# Altium schematic sheet Power.SchDoc
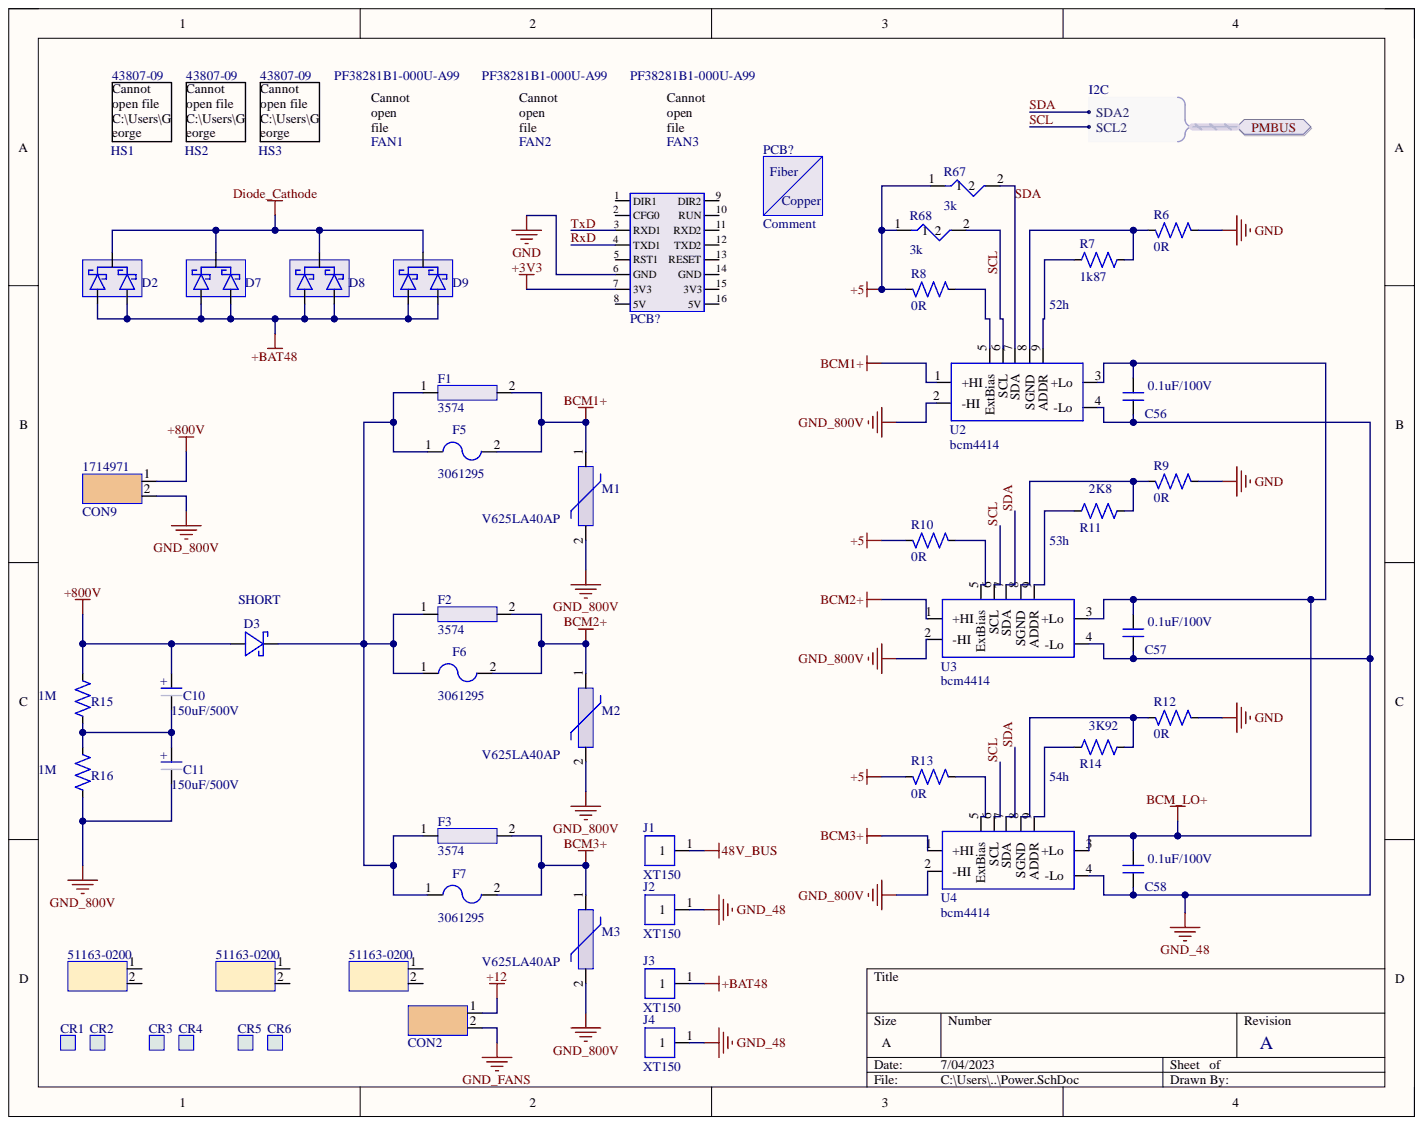
[diagram p0: Power.SchDoc]

## Parts
C10  150uF/500V  [Cap_THT_O22mm-Lead10mm]
C11  150uF/500V  [Cap_THT_O22mm-Lead10mm]
C56  0.1uF/100V  [C0805]
C57  0.1uF/100V  [C0805]
C58  0.1uF/100V  [C0805]
CON2  22-28-4024  [Con1x02_2.54]  (value not drawn)
CON9  1714971  [CON1X02_9.52mm]
CR1  (no value)
CR2  (no value)
CR3  (no value)
CR4  (no value)
CR5  (no value)
CR6  (no value)
D2  VS-80CPQ020-N3  [TO-247]  (value not drawn)
D3  (no value)  [TO-220]
D7  VS-80CPQ020-N3  [TO-247]  (value not drawn)
D8  VS-80CPQ020-N3  [TO-247]  (value not drawn)
D9  VS-80CPQ020-N3  [TO-247]  (value not drawn)
F1  3574  [5AG_Holder]
F2  3574  [5AG_Holder]
F3  3574  [5AG_Holder]
F5  3061295
F6  3061295
F7  3061295
FAN1  PF38281B1-000U-A99
FAN2  PF38281B1-000U-A99
FAN3  PF38281B1-000U-A99
HS1  43807-09
HS2  43807-09
HS3  43807-09
J1  XT150  [XT150]
J2  XT150  [XT150]
J3  XT150  [XT150]
J4  XT150  [XT150]
M1  V625LA40AP  [V625LA40AP]
M2  V625LA40AP  [V625LA40AP]
M3  V625LA40AP  [V625LA40AP]
PCB?  Comment  [TP-Link MC220L]
PCB?  Uart to Ethernet Converter  [uart2ethernet]  (value not drawn)
R6  0R  [R0402]
R7  1k87  [R0402]
R8  0R  [R0402]
R9  0R  [R0402]
R10  0R  [R0402]
R11  2K8  [R0402]
R12  0R  [R0402]
R13  0R  [R0402]
R14  3K92  [R0402]
R15  1M  [R2010]
R16  1M  [R2010]
R67  3k  [0805_3k]
R68  3k  [0805_3k]
U2  bcm4414  [BCM4414]
U3  bcm4414  [BCM4414]
U4  bcm4414  [BCM4414]

## Ports
PMBUS, PMBUS.SCL2, PMBUS.SDA2

## Nets
48V_BUS: J1.1
+3V3: PCB?.7(3V3)
+5: R8.2 R10.2 R13.2 R67.1 R68.1
+12: CON2.1
+800V: C10.1 CON9.1 D3.2 R15.2
+BAT48: D2.1 D2.3 D7.1 D7.3 D8.1 D8.3 D9.1 D9.3 J3.1
BCM1+: F1.2 F5.2 M1.1 U2.1(+HI)
BCM2+: F2.2 F6.2 M2.1 U3.1(+HI)
BCM3+: F3.2 F7.2 M3.1 U4.1(+HI)
BCM_LO+: C56.1 C57.1 C58.1 U2.3(+Lo) U3.3(+Lo) U4.3(+Lo)
Diode_Cathode: D2.2 D7.2 D8.2 D9.2
GND: PCB?.6(GND) R6.2 R9.2 R12.2
GND_48: C56.2 C57.2 C58.2 J2.1 J4.1 U2.4(-Lo) U3.4(-Lo) U4.4(-Lo)
GND_800V: C11.2 CON9.2 M1.2 M2.2 M3.2 R16.1 U2.2(-HI) U3.2(-HI) U4.2(-HI)
GND_FANS: CON2.2
RxD: PCB?.4(TXD1)
SCL: R68.2 U2.6(SCL) U3.6(SCL) U4.6(SCL) ; port PMBUS
SDA: R67.2 U2.7(SDA) U3.7(SDA) U4.7(SDA) ; port PMBUS
TxD: PCB?.3(RXD1)
NetC10_2: C10.2 C11.1 R15.1 R16.2
NetD3_1: D3.1 F1.1 F2.1 F3.1 F5.1 F6.1 F7.1
NetR6_1: R6.1 R7.1 U2.8(SGND)
NetR7_2: R7.2 U2.9(ADDR)
NetR8_1: R8.1 U2.5(ExtBias)
NetR9_1: R9.1 R11.2 U3.8(SGND)
NetR10_1: R10.1 U3.5(ExtBias)
NetR11_1: R11.1 U3.9(ADDR)
NetR12_1: R12.1 R14.2 U4.8(SGND)
NetR13_1: R13.1 U4.5(ExtBias)
NetR14_1: R14.1 U4.9(ADDR)

Not connected: PCB? 1(DIR1) 2(CFG0) 5(RST1) 8(5V) 9(nnis\De) 10(sktop\i) 11(RXD2) 12(File) 13(RESET) 14(GND) 15(3V3) 16(5V)

## Library data of the parts
C10 C11 = ESG157M500AQ5AA: Cap, 150UF, 500V, Alu Elec, Radial; Manufacturer: KEMET; Database Table Name: components; Life (Hours): 5000Hour; Polarity: Polar; Tolerance: 20%; Capacitance: 150uF; Case/Package: Radial; Component Kind: Standard; ComponentLink1Description: https://api.kemet.com/component-edge/download; ComponentLink1URL: https://api.kemet.com/component-edge/download; Library Name: Resistors-Capacitors.DbLib; Manufacturer Part Number: ESG157M500AQ5AA; Max Operating Temperature: 105°C; Min Operating Temperature: -40°C; Mount: Through Hole; Packaging: Bulk; Part Number: ESG157M500AQ5AA; Pricing 1: 1=7,95000, 10=7,08000, 50=6,19000, 100=5,6600; RoHS: Yes; RoHS Compliant: No; Stock 1: 2172; Supplier 1: Mouser; Supplier Part Number 1: 80-ESG157M500AQ5AA; Voltage Rating: 500V
C56-C58 = CL21B104KCFNNNE: CAP CER 0.1UF 100V X7R 0805; Manufacturer: Samsung; Capacitance: 100nF; Database Table Name: components; Tolerance: 10%; Case Code (Imperial): 0805; Case Code (Metric): 2012; Case/Package: 0805; Category: Ceramic Capacitors; Component Kind: Standard; ComponentLink1Description: https://datasheet.ciiva.com/26936/getdatashee; ComponentLink1URL: https://datasheet.ciiva.com/26936/getdatashee; Depth: 1.25mm; Dielectric: X7R; Length: 2mm; Library Name: Resistors-Capacitors.DbLib; Manufacturer Part Number: CL21B104KCFNNNE; Max Operating Temperature: 125°C; Min Operating Temperature: -55°C; Mount: Surface Mount; Packaging: Tape and Reel; Part Number: CL21B104KCFNNNE; Pricing 1: 1=0,10000, 10=0,03000, 50=0,03000, 100=0,0250; Radiation Hardening: No; RoHS: Yes; RoHS Compliant: Yes; Series: CL; Stock 1: 299285; Supplier 1: Mouser; Supplier Part Number 1: 187-CL21B104KCFNNNE; Thickness: 0.053inch; Voltage Rating: 100V; Width: 0.049inch
CON2 = 22-28-4024: Breakaway Header; KK 254; Vertical; 2 Circuit; Manufacturer: Molex; Database Table Name: connectors; Category: Headers and Wire Housings; Component Kind: Standard; ComponentLink1Description: https://datasheet.ciiva.com/10088/0022284024-; ComponentLink1URL: https://datasheet.ciiva.com/10088/0022284024-; ComponentLink2Description: https://datasheet.ciiva.com/5153/0022284024-p; ComponentLink2URL: https://datasheet.ciiva.com/5153/0022284024-p; ComponentLink3Description: https://datasheet.ciiva.com/30120/63280022284; ComponentLink3URL: https://datasheet.ciiva.com/30120/63280022284; ComponentLink4Description: https://datasheet.ciiva.com/18451/70090858-18; ComponentLink4URL: https://datasheet.ciiva.com/18451/70090858-18; ComponentLink5Description: https://datasheet.ciiva.com/1433/1856632-1433; ComponentLink5URL: https://datasheet.ciiva.com/1433/1856632-1433; Library Name: Connectors.DbLib; Manufacturer Part Number: 22-28-4024; Part Number: 22-28-4024; Pricing 1: 1=0,22000, 10=0,17700, 50=0,17700, 100=0,1270; RoHS: Yes; Stock 1: 11115; Supplier 1: Mouser; Supplier Part Number 1: 538-22-28-4024
CON9 = 1714971: Terminal Block; 32 A; 690 V; 9.5 mm; 24 to 10; Manufacturer: Phoenix Contact; Database Table Name: connectors; Category: Test Probes, Leads and Clips; Component Kind: Standard; Library Name: Connectors.DbLib; Manufacturer Part Number: 1714971; Part Number: 1714971; Pricing 1: 1=1,98000, 10=1,93000, 50=1,80000, 100=1,6500; RoHS: Yes; Stock 1: 10332; Supplier 1: Mouser; Supplier Part Number 1: 651-1714971
CR1-CR6 = 0507528200: 2.50mm Pitch, Mini-Lock Female Crimp Terminal; Manufacturer: Molex; Database Table Name: connectors; Category: Connectors; Component Kind: Standard; ComponentLink1Description: https://www.molex.com/pdm_docs/sd/507528200_s; ComponentLink1URL: https://www.molex.com/pdm_docs/sd/507528200_s; Library Name: Connectors.DbLib; Manufacturer Part Number: 0507528200; Part Number: 0507528200; Pricing 1: 1=0,12000, 10=0,12000, 50=0,12000, 100=0,1200; RoHS: Yes; Stock 1: 316369; Supplier 1: Mouser; Supplier Part Number 1: 538-50752-8200
D2 D7-D9 = VS-80CPQ020-N3: Diode Schottky Rectifier 20V 80A 3-Pin TO-247; Manufacturer: Vishay; Average Rectified Current: 40A; Database Table Name: components; Element Configuration: Common Cathode; Forward Voltage: 550mV; Max Forward Surge Current (Ifsm): 2.2kA; Max Reverse Current: 5.5mA; Case/Package: TO-247-3; Category: Rectifier Diodes; Component Kind: Standard; ComponentLink1Description: http://www.vishay.com/docs/94256/80cpq020.pdf; ComponentLink1URL: http://www.vishay.com/docs/94256/80cpq020.pdf; Forward Current: 40A; Height: 20.7mm; Length: 15.87mm; Library Name: Diodes.DbLib; Manufacturer Part Number: VS-80CPQ020-N3; Max Operating Temperature: 150°C; Max Repetitive Reverse Voltage (Vrrm): 20V; Max Reverse Voltage (DC): 20V; Min Operating Temperature: -55°C; Mount: Through Hole; Number of Pins: 3; Packaging: Rail/Tube; Part Number: VS-80CPQ020-N3; Peak Non-Repetitive Surge Current: 2.2kA; Pricing 1: 1=5,72000, 10=5,14000, 50=5,14000, 100=4,2100; RoHS: Yes; RoHS Compliant: Yes; Stock 1: 5110; Supplier 1: Mouser; Supplier Part Number 1: 78-VS-80CPQ020-N3; Width: 5.31mm
D3 = VT10200C-E3/4W: VT10200C-E3 10 A 200 V Trench MOS Barrier Sch; Manufacturer: Vishay Semiconductors; Average Rectified Current: 5A; Database Table Name: components; Element Configuration: Common Cathode; ESD Protection: No; Forward Current: 10A; Forward Voltage: 580mV; Impedance: 95R; Max Forward Surge Current (Ifsm): 80A; Max Power Dissipation: 350mW; Max Repetitive Reverse Voltage (Vrrm): 200V; Max Reverse Current: 150uA; Max Reverse Leakage Current: 10uA; Output Current: 50mA; Test Current: 5mA; Tolerance: 7%; Voltage: 3V; Voltage Tolerance: 5%; Case/Package: TO-220-3; Category: Rectifier Diodes; Component Kind: Standard; ComponentLink1Description: https://datasheet.ciiva.com/24139/vt10200c-27; ComponentLink1URL: https://datasheet.ciiva.com/24139/vt10200c-27; ComponentLink2Description: https://datasheet.ciiva.com/25623/0900766b810; ComponentLink2URL: https://datasheet.ciiva.com/25623/0900766b810; ComponentLink3Description: https://datasheet.ciiva.com/8347/ds18001-8347; ComponentLink3URL: https://datasheet.ciiva.com/8347/ds18001-8347; ComponentLink4Description: https://datasheet.ciiva.com/890/ds18001-89008; ComponentLink4URL: https://datasheet.ciiva.com/890/ds18001-89008; ComponentLink5Description: https://datasheet.ciiva.com/890/ds18001-89009; ComponentLink5URL: https://datasheet.ciiva.com/890/ds18001-89009; ComponentLink6Description: https://datasheet.ciiva.com/10637/bzx84c2v4lt; ComponentLink6URL: https://datasheet.ciiva.com/10637/bzx84c2v4lt; ComponentLink7Description: https://datasheet.ciiva.com/24019/bzx84c2v4lt; ComponentLink7URL: https://datasheet.ciiva.com/24019/bzx84c2v4lt; Contact Plating: Tin,Matte; Halogen Free: Halogen Free; Height: 1mm; Lead Free: Lead Free; Length: 2.9mm; Library Name: Diodes.DbLib; Manufacturer Part Number: VT10200C-E3/4W; Max Operating Temperature: 150°C; Max Reverse Voltage (DC): 200V; Min Operating Temperature: -40°C; Mount: Through Hole; Number of Pins: 3; Package Quantity: 50; Packaging: Rail/Tube; Part Number: VT10200C-E3/4W; Peak Non-Repetitive Surge Current: 80A; Power Dissipation: 350mW; Power Rating: 350mW; Pricing 1: 1=1,25000, 10=1,13000, 50=1,13000, 100=0,8760; RoHS: Yes; RoHS Compliant: Yes; Series: TMBS®; Stock 1: 745; Supplier 1: Mouser; Supplier Part Number 1: 78-VT10200C-E3/4W; Termination: SMD/SMT; Voltage Rating (DC): 3V; Weight: 0.066315oz; Width: 1.3mm; Working Voltage: 3V; Zener Current: 5mA; Zener Voltage: 3V
F1-F3 = 3574: Adafruit Feather nRF52 Pro with myNewt Bootlo; Manufacturer: Keystone Electronics; Database Table Name: components; Case/Package: SMD/SMT; Category: Fuse Holders; Component Kind: Standard; Library Name: Switches.DbLib; Manufacturer Part Number: 3574; Mount: Surface Mount; Part Number: 3574; Pricing 1: 1=3,57000, 10=3,57000, 50=3,14000, 100=2,8600; RoHS: Yes; Stock 1: 401; Supplier 1: Mouser; Supplier Part Number 1: 534-3574
F5-F7 = 3061295: Fuse10.3x38 mm up to 1000 V DCgPVcharacterist; Manufacturer: Phoenix Contact; Current Rating: 2A; Database Table Name: components; Voltage Rating (DC): 1kV; Case/Package: Ceramic; Category: Fuses; Component Kind: Standard; Library Name: Switches.DbLib; Manufacturer Part Number: 3061295; Mount: Holder; Package Quantity: 10; Packaging: Bulk; Part Number: 3061295; Pricing 1: 1=7,57000, 10=6,60000, 50=6,07000, 100=5,6100; RoHS: Yes; Stock 1: 317; Supplier 1: Mouser; Supplier Part Number 1: 651-3061295; Termination: Clip
FAN1-FAN3 = PF38281B1-000U-A99: Fan; DC; 12V; 18.1CFM; 315A; 3.78W; 16000RPM;; Manufacturer: Sunon; Database Table Name: components; Case/Package: Axial; Category: Capacitors; Component Kind: Standard; Library Name: Circuit_Protection.DbLib; Manufacturer Part Number: PF38281B1-000U-A99; Part Number: PF38281B1-000U-A99; Pricing 1: 1=18,30000, 10=16,71700, 25=16,08240, 50=15,0; RoHS: Yes; Stock 1: 56; Supplier 1: Digi-Key; Supplier Part Number 1: 259-1748-ND
HS1-HS3 = 43807-09: Heat Sink For Dcdc Cnvtr/arrays; Manufacturer: Vicor; Database Table Name: components; Component Kind: Standard; ComponentLink1Description: http://asp.vicorpower.com/CADPDF/ETL0TO.PDF?s; ComponentLink1URL: http://asp.vicorpower.com/CADPDF/ETL0TO.PDF?s; Library Name: Circuit_Protection.DbLib; Manufacturer Part Number: 43807-09; Part Number: 43807-09; Pricing 1: 1=74,35000, 10=59,06000, 50=50,67000, 100=50,; RoHS: No; Supplier 1: Mouser; Supplier Part Number 1: 492-43807-09
M1-M3 = V625LA40AP: LA Series 825 VDC 625 V RMS 1650 V Clamp 4500; Manufacturer: Littelfuse; Airflow: 18.1CFM; Approvals: cURus; Bearing Type: Ball; Capacitance: 210pF; Clamping Current: 50A; Clamping Voltage: 1.65kV; Database Table Name: components; DC Cold Resistance: 32mR; DC Resistance (DCR): 8.5mR; Energy: 125J; Fuse Type: Board Mount (Cartridge Style Excluded); Inductance: 33.33uH; Lead/Base Style: Radial; Max Current Rating: 4A; Max Output Current: 4.5kA; Max Power Dissipation: 3.78W; Max Varistor Voltage: 1.1kV; Max Voltage Rating (AC): 625V; Max Voltage Rating (DC): 825V; Min Varistor Voltage: 900V; Noise: 53.8dBA; Noise Level: 53.8 dBA; Number of Circuits: 1; Number of Lines: 2; RPM: 16000RPM; Case Code (Imperial): 2410; Case Code (Metric): 6125; Case/Package: Metal; Category: Varistors; Clamping Voltage-Max: 1.65kV; Component Kind: Standard; ComponentLink1Description: https://datasheet.ciiva.com/6992/littelfuse-m; ComponentLink1URL: https://datasheet.ciiva.com/6992/littelfuse-m; ComponentLink2Description: https://datasheet.ciiva.com/4543/littelfuse-m; ComponentLink2URL: https://datasheet.ciiva.com/4543/littelfuse-m; ComponentLink3Description: https://datasheet.ciiva.com/16345/littelfuse-; ComponentLink3URL: https://datasheet.ciiva.com/16345/littelfuse-; ComponentLink4Description: https://datasheet.ciiva.com/8071/482376-80710; ComponentLink4URL: https://datasheet.ciiva.com/8071/482376-80710; ComponentLink5Description: http://www.farnell.com/datasheets/2828568.pdf; ComponentLink5URL: http://www.farnell.com/datasheets/2828568.pdf; ComponentLink6Description: http://www.farnell.com/datasheets/2062550.pdf; ComponentLink6URL: http://www.farnell.com/datasheets/2062550.pdf; ComponentLink7Description: http://www.farnell.com/datasheets/2062551.pdf; ComponentLink7URL: http://www.farnell.com/datasheets/2062551.pdf; ComponentLink8Description: https://www.tracopower.com/products/tck076.pd; ComponentLink8URL: https://www.tracopower.com/products/tck076.pd; ComponentLink9Description: http://www.farnell.com/datasheets/2062551.pdf; ComponentLink9URL: http://www.farnell.com/datasheets/2062551.pdf; ComponentLink10Description: http://www.farnell.com/datasheets/1951860.pdf; ComponentLink10URL: http://www.farnell.com/datasheets/1951860.pdf; ComponentLink11Description: http://www.farnell.com/datasheets/1951860.pdf; ComponentLink11URL: http://www.farnell.com/datasheets/1951860.pdf; ComponentLink12Description: https://katalog.we-online.de/pbs/datasheet/74; ComponentLink12URL: https://katalog.we-online.de/pbs/datasheet/74; ComponentLink13Description: http://www.belfuse.com/resources/CircuitProte; ComponentLink13URL: http://www.belfuse.com/resources/CircuitProte; ComponentLink14Description: http://www.sunon.com/uFiles/file/03_products/; ComponentLink14URL: http://www.sunon.com/uFiles/file/03_products/; Current Rating: 50A; Depth: 8.3mm; Diameter: 14mm; Height: 1.772inch; Housing Material: Plastic; Lead Free: Lead Free; Lead Pitch: 8.5mm; Length: 1.457inch; Library Name: Circuit_Protection.DbLib; Manufacturer Part Number: V625LA40AP; Max Operating Temperature: 85°C; Max Surge Current: 4.5kA; Min Operating Temperature: -55°C; Mount: Through Hole; Package Quantity: 500; Packaging: Bulk; Part Number: V625LA40AP; Power Rating: 3.78W; Pricing 1: 1=1,79000, 10=1,62000, 50=1,62000, 100=1,3000; Radiation Hardening: No; Reach SVHC Compliant: Yes; RoHS: Yes; RoHS Compliant: Yes; Series: LA; Stock 1: 488; Supplier 1: Mouser; Supplier Part Number 1: 576-V625LA40AP; Termination: Radial; Varistor Voltage: 1.1kV; Voltage Rating: 825V; Voltage Rating (AC): 625V; Voltage Rating (DC): 825V; Weight: 0.088lb; Width: 8.3mm
PCB? = TP-Link MC220L: Gigabit SFP to RJ45 Fiber Media Converter; Database Table Name: components; Component Kind: Standard; Library Name: Microcontrollers.DbLib; Part Number: TP-Link MC220L; Supplier 1: Amazon
PCB? = 2-CH-UART-TO-ETH: The 2-CH UART TO ETH provides an easy way to; Database Table Name: hmi; Component Kind: Standard; ComponentLink1URL: https://www.waveshare.com/wiki/2-CH_UART_TO_E; Library Name: HMI.DbLib; Part Number: 2-CH-UART-TO-ETH; Supplier 1: Waveshare
R6 R8-R10 R12 R13 = AC0402FR-070RL: AC Series 0402 0 Ohm JUMPER Thick Film Chip R; Manufacturer: Yageo; Database Table Name: components; Power Rating: 62.5mW; Temperature Coefficient: 200ppm/°C; Tolerance: 1%; Voltage Rating: 50V; Case Code (Imperial): 0402; Case Code (Metric): 1005; Case/Package: 0402; Category: Chip SMD Resistors; Component Kind: Standard; ComponentLink1Description: https://datasheet.ciiva.com/12656/pyu-ac-51-r; ComponentLink1URL: https://datasheet.ciiva.com/12656/pyu-ac-51-r; Library Name: Resistors-Capacitors.DbLib; Manufacturer Part Number: AC0402FR-070RL; Max Operating Temperature: 155°C; Min Operating Temperature: -55°C; Packaging: Tape and Reel; Part Number: AC0402FR-070RL; Pricing 1: 1=0,10000, 10=0,02000, 50=0,02000, 100=0,0090; Resistance: 0R; RoHS: Yes; Series: AC; Stock 1: 1298506; Supplier 1: Mouser; Supplier Part Number 1: 603-AC0402FR-070RL; Termination: SMD/SMT
R7 = CR0402-FX-1871GLF: Res Thick Film 0402 1.87K Ohm 1% 1/16W ±100pp; Manufacturer: Bourns; Database Table Name: components; Power Rating: 62.5mW; Resistance: 1.87kR; Temperature Coefficient: 100ppm/°C; Voltage Rating: 50V; Case Code (Imperial): 0402; Case Code (Metric): 1005; Case/Package: 0402; Category: Chip SMD Resistors; Component Kind: Standard; ComponentLink1Description: http://components.arrow.com/part/dataview/429; ComponentLink1URL: http://components.arrow.com/part/dataview/429; ComponentLink2Description: http://www.onlinecomponents.com/datasheet/cr0; ComponentLink2URL: http://www.onlinecomponents.com/datasheet/cr0; ComponentLink3Description: http://www.bourns.com/data/global/pdfs/CR0402; ComponentLink3URL: http://www.bourns.com/data/global/pdfs/CR0402; ComponentLink4Description: http://www.bourns.com/pdfs/CR0402.pdf?src-sup; ComponentLink4URL: http://www.bourns.com/pdfs/CR0402.pdf?src-sup; ComponentLink5Description: https://www.bourns.com/docs/Product-Datasheet; ComponentLink5URL: https://www.bourns.com/docs/Product-Datasheet; ComponentLink6Description: http://www.bourns.com/docs/Product-Datasheets; ComponentLink6URL: http://www.bourns.com/docs/Product-Datasheets; Contact Plating: Tin; Height: 0.35mm; Length: 1mm; Library Name: Resistors-Capacitors.DbLib; Manufacturer Part Number: CR0402-FX-1871GLF; Max Operating Temperature: 125°C; Min Operating Temperature: -55°C; Mount: Surface Mount; Package Quantity: 10000; Packaging: Tape and Reel; Part Number: CR0402-FX-1871GLF; Pricing 1: 1=0,10000, 10=0,03700, 50=0,03700, 100=0,0210; Radiation Hardening: No; Resistor Type: Thick Film; RoHS: Yes; RoHS Compliant: Yes; Series: CR0402; Stock 1: 22611; Supplier 1: Mouser; Supplier Part Number 1: 652-CR0402-FX1871GLF; Termination: SMD/SMT; Thickness: 356um; Tolerance: 1%; Width: 0.5mm
R11 = RC0402FR-072K8L: Res Thick Film 0402 2.8K Ohm 1% 1/16W ±100ppm; Manufacturer: Yageo; Database Table Name: components; Max Power Dissipation: 63mW; Power Rating: 62.5mW; Resistance: 2.8kR; Temperature Coefficient: 100ppm/°C; Voltage Rating: 50V; Case Code (Imperial): 0402; Case Code (Metric): 1005; Case/Package: 0402; Category: Chip SMD Resistors; Component Kind: Standard; ComponentLink1Description: https://datasheet.ciiva.com/16868/pyu-rc0402-; ComponentLink1URL: https://datasheet.ciiva.com/16868/pyu-rc0402-; ComponentLink2Description: https://datasheet.ciiva.com/8894/pyu-rc0402-5; ComponentLink2URL: https://datasheet.ciiva.com/8894/pyu-rc0402-5; Construction: Rectangular; Contact Plating: Tin,Matte; Depth: 0.5mm; Features: Moisture Resistant; Height: 0.016inch; Length: 1mm; Library Name: Resistors-Capacitors.DbLib; Manufacturer Part Number: RC0402FR-072K8L; Max Operating Temperature: 155°C; Military Standard: Not; Min Operating Temperature: -55°C; Number of Terminations: 2; Packaging: Tape and Reel; Part Number: RC0402FR-072K8L; Pricing 1: 1=0.10000, 10=0.01200, 50=0.01200, 100=0.0040; Resistor Type: Thick Film; RoHS: Yes; RoHS Compliant: Yes; Series: RC; Supplier 1: Mouser; Supplier Part Number 1: 603-RC0402FR-072K8L; Termination: SMD/SMT; Tolerance: 1%; Width: 0.02inch
R14 = CRCW04023K92FKED: Res Thick Film 0402 3.92K Ohm 1% 1/16W ±100pp; Manufacturer: Vishay; Database Table Name: components; Max Power Dissipation: 63mW; Resistance: 3.92kR; Temperature Coefficient: 100ppm/°C; Voltage Rating: 50V; Case Code (Imperial): 0402; Case Code (Metric): 1005; Case/Package: 0402; Category: Chip SMD Resistors; Component Kind: Standard; ComponentLink1Description: https://datasheet.ciiva.com/11818/0900766b80d; ComponentLink1URL: https://datasheet.ciiva.com/11818/0900766b80d; ComponentLink2Description: https://datasheet.ciiva.com/2464/dcrcwe3-2464; ComponentLink2URL: https://datasheet.ciiva.com/2464/dcrcwe3-2464; ComponentLink3Description: https://datasheet.ciiva.com/2464/dcrcwe3-2464; ComponentLink3URL: https://datasheet.ciiva.com/2464/dcrcwe3-2464; ComponentLink4Description: https://datasheet.ciiva.com/7679/1697337-7679; ComponentLink4URL: https://datasheet.ciiva.com/7679/1697337-7679; ComponentLink5Description: https://datasheet.ciiva.com/16771/70201119-16; ComponentLink5URL: https://datasheet.ciiva.com/16771/70201119-16; ComponentLink6Description: https://datasheet.ciiva.com/16394/dcrcwe3-163; ComponentLink6URL: https://datasheet.ciiva.com/16394/dcrcwe3-163; ComponentLink7Description: https://datasheet.ciiva.com/5939/1697337-5939; ComponentLink7URL: https://datasheet.ciiva.com/5939/1697337-5939; ComponentLink8Description: https://datasheet.ciiva.com/22869/70201119-22; ComponentLink8URL: https://datasheet.ciiva.com/22869/70201119-22; ComponentLink9Description: https://datasheet.ciiva.com/2464/dcrcwe3-2464; ComponentLink9URL: https://datasheet.ciiva.com/2464/dcrcwe3-2464; ComponentLink10Description: https://datasheet.ciiva.com/7679/1697337-7679; ComponentLink10URL: https://datasheet.ciiva.com/7679/1697337-7679; Construction: Rectangular; Contact Plating: Tin; Depth: 0.5mm; Features: Automotive AEC-Q200; Height: 0.35mm; Length: 1mm; Library Name: Resistors-Capacitors.DbLib; Manufacturer Part Number: CRCW04023K92FKED; Max Operating Temperature: 155°C; Military Standard: Not; Min Operating Temperature: -55°C; Mount: Surface Mount; Number of Pins: 2; Number of Terminations: 2; Package Quantity: 10000; Packaging: Tape and Reel; Part Number: CRCW04023K92FKED; Power Rating: 63mW; Pricing 1: 1=0,10000, 10=0,04200, 50=0,04200, 100=0,0170; Radiation Hardening: No; Ratings: AEC-Q200; Resistance Tolerance: 1%; Resistor Type: Thick Film; RoHS: Yes; RoHS Compliant: Yes; Series: CRCW; Size Code: 0402; Stock 1: 6930; Supplier 1: Mouser; Supplier Part Number 1: 71-CRCW0402-3.92K-E3; Technology: THICK FILM; Termination: SMD/SMT; Tolerance: 1%; Weight: 2.3E-05oz; Width: 0.5mm
R15 R16 = HV732HTTE1004F: Res Thick Film 2010 1M Ohm 1% 0.5W(1/2W) ±100; Manufacturer: KOA Speer; Database Table Name: components; Power Rating: 500mW; Resistance: 1MR; Temperature Coefficient: 100ppm/°C; Voltage Rating: 2kV; Case Code (Imperial): 2010; Case Code (Metric): 5025; Case/Package: 2010; Category: Chip SMD Resistors; Component Kind: Standard; ComponentLink1Description: http://www.koaspeer.com/catimages/Products/HV; ComponentLink1URL: http://www.koaspeer.com/catimages/Products/HV; Height: 0.6mm; Length: 5mm; Library Name: Resistors-Capacitors.DbLib; Manufacturer Part Number: HV732HTTE1004F; Max Operating Temperature: 155°C; Min Operating Temperature: -55°C; Package Quantity: 4000; Packaging: Tape and Reel; Part Number: HV732HTTE1004F; Pricing 1: 1=0,64000, 10=0,52300, 50=0,52300, 100=0,3590; Resistor Type: Thick Film; RoHS: Yes; Stock 1: 8447; Supplier 1: Mouser; Supplier Part Number 1: 660-HV732HTTE1004F; Termination: SMD/SMT; Tolerance: 1%; Voltage Rating (DC): 2kV; Width: 2.5mm
J1-J4 = XT150: Component Kind: Standard; Library Name: Schlib1.SchLib
R67 R68 = 3k: Component Kind: Standard; Library Name: Schlib1.SchLib
U2-U4 = bcm4414: Component Kind: Standard; Library Name: Schlib1.SchLib
